# Altium schematic sheet [14] PWR2.SchDoc("[14] PWR2")
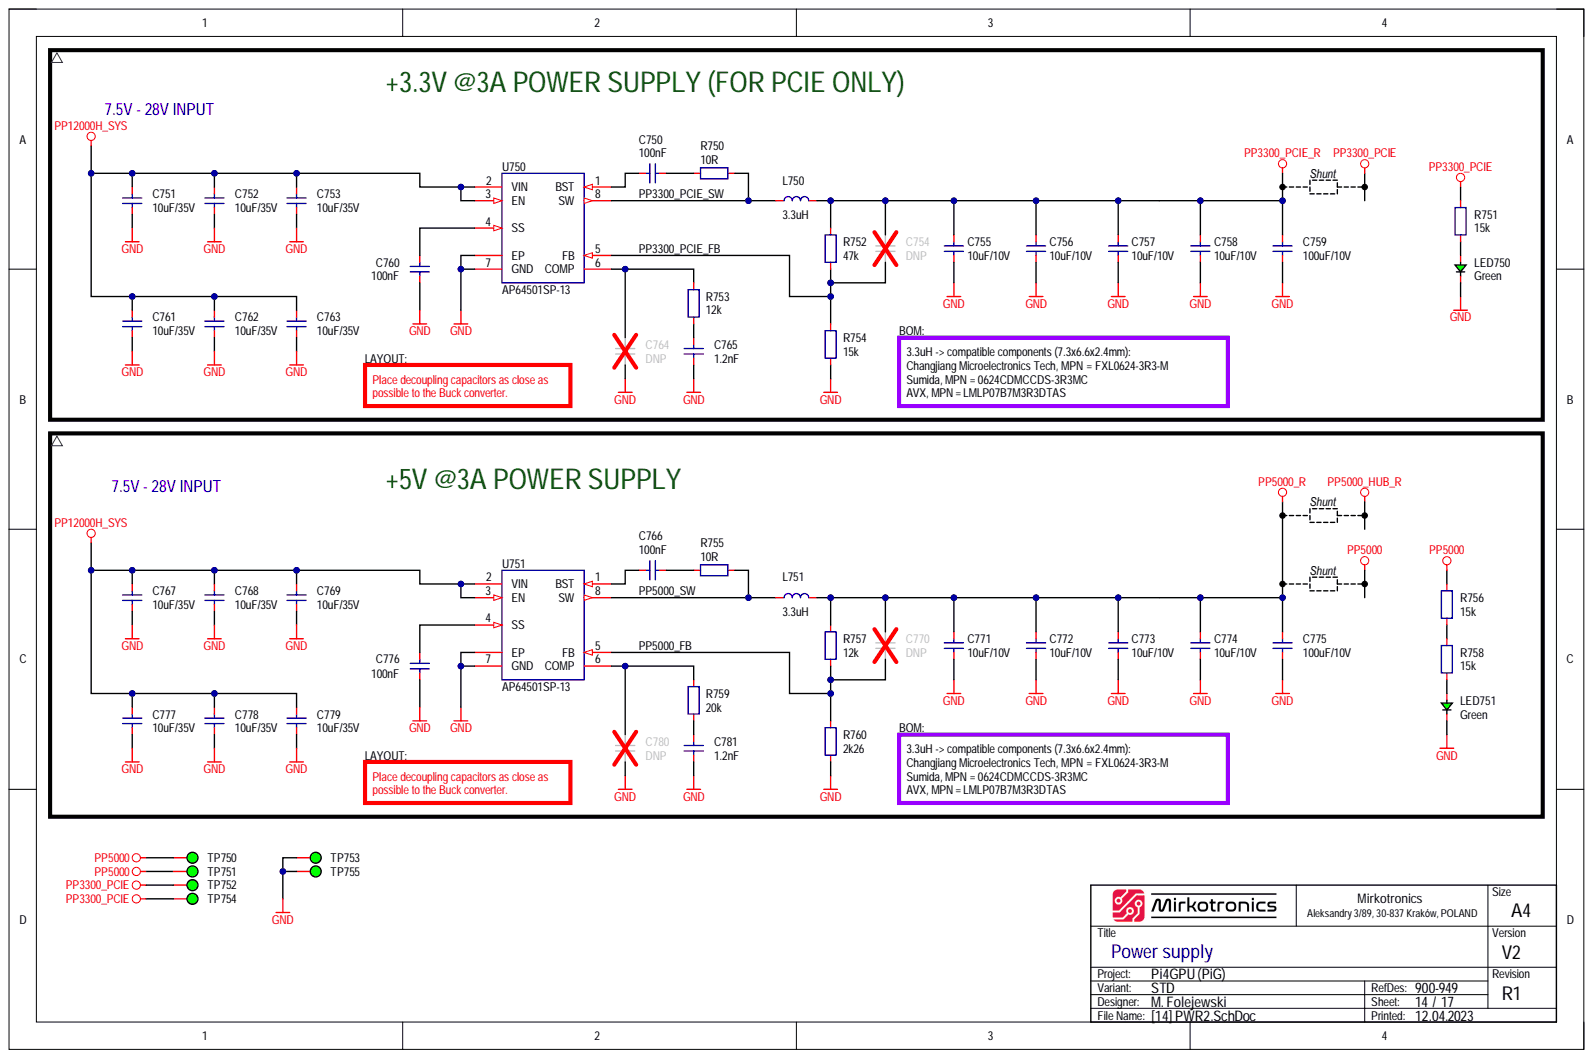
[diagram p0: [14] PWR2.SchDoc]

## Parts
C750  100nF  [CAP_0603_R]
C751  10uF/35V  [CAP_1206_R]
C752  10uF/35V  [CAP_1206_R]
C753  10uF/35V  [CAP_1206_R]
C754  DNP  [CAP_0603_R]
C755  10uF/10V  [CAP_0603_R]
C756  10uF/10V  [CAP_0603_R]
C757  10uF/10V  [CAP_0603_R]
C758  10uF/10V  [CAP_0603_R]
C759  100uF/10V  [CAP_1206_R]
C760  100nF  [CAP_0603_R]
C761  10uF/35V  [CAP_1206_R]
C762  10uF/35V  [CAP_1206_R]
C763  10uF/35V  [CAP_1206_R]
C764  DNP  [CAP_0603_R]
C765  1.2nF  [CAP_0603_R]
C766  100nF  [CAP_0603_R]
C767  10uF/35V  [CAP_1206_R]
C768  10uF/35V  [CAP_1206_R]
C769  10uF/35V  [CAP_1206_R]
C770  DNP  [CAP_0603_R]
C771  10uF/10V  [CAP_0603_R]
C772  10uF/10V  [CAP_0603_R]
C773  10uF/10V  [CAP_0603_R]
C774  10uF/10V  [CAP_0603_R]
C775  100uF/10V  [CAP_1206_R]
C776  100nF  [CAP_0603_R]
C777  10uF/35V  [CAP_1206_R]
C778  10uF/35V  [CAP_1206_R]
C779  10uF/35V  [CAP_1206_R]
C780  DNP  [CAP_0603_R]
C781  1.2nF  [CAP_0603_R]
L750  3.3uH  [IND_SUM_0624CDMCCDS_700X660X240_R]
L751  3.3uH  [IND_SUM_0624CDMCCDS_700X660X240_R]
LED750  Green  [LED_0805_GRN_R]
LED751  Green  [LED_0805_GRN_R]
R750  10R  [RES_0603_R]
R751  15k  [RES_0603_R]
R752  47k  [RES_0603_R]
R753  12k  [RES_0603_R]
R754  15k  [RES_0603_R]
R755  10R  [RES_0603_R]
R756  15k  [RES_0603_R]
R757  12k  [RES_0603_R]
R758  15k  [RES_0603_R]
R759  20k  [RES_0603_R]
R760  2k26  [RES_0603_R]
TP750  ICT  [PCB_TP_ICT_100]  (value not drawn)  (no BOM)
TP751  ICT  [PCB_TP_ICT_100]  (value not drawn)  (no BOM)
TP752  ICT  [PCB_TP_ICT_100]  (value not drawn)  (no BOM)
TP753  ICT  [PCB_TP_ICT_100]  (value not drawn)  (no BOM)
TP754  ICT  [PCB_TP_ICT_100]  (value not drawn)  (no BOM)
TP755  ICT  [PCB_TP_ICT_100]  (value not drawn)  (no BOM)
U750  AP64501SP-13  [ICC_SOIC8N_TP_R]
U751  AP64501SP-13  [ICC_SOIC8N_TP_R]

## Nets
GND: C751.1 C752.1 C753.1 C755.1 C756.1 C757.1 C758.1 C759.1 C760.1 C761.1 C762.1 C763.1 C764.1 C765.1 C767.1 C768.1 C769.1 C771.1 C772.1 C773.1 C774.1 C775.1 C776.1 C777.1 C778.1 C779.1 C780.1 C781.1 LED750.1 LED751.1 R754.1 R760.1 TP753.1 TP755.1 U750.7(GND) U750.EP U751.7(GND) U751.EP
PP3300_PCIE: R751.2 TP752.1 TP754.1
PP3300_PCIE_R: C754.2 C755.2 C756.2 C757.2 C758.2 C759.2 L750.2 R752.2
PP5000: R756.2 TP750.1 TP751.1
PP5000_R: C770.2 C771.2 C772.2 C773.2 C774.2 C775.2 L751.2 R757.2
PP12000H_SYS: C751.2 C752.2 C753.2 C761.2 C762.2 C763.2 C767.2 C768.2 C769.2 C777.2 C778.2 C779.2 U750.2(VIN) U750.3(EN) U751.2(VIN) U751.3(EN)
PP3300_PCIE_FB: C754.1 R752.1 R754.2 U750.5(FB)
PP3300_PCIE_SW: L750.1 R750.2 U750.8(SW)
PP5000_FB: C770.1 R757.1 R760.2 U751.5(FB)
PP5000_SW: L751.1 R755.2 U751.8(SW)
NetC750_1: C750.1 U750.1(BST)
NetC750_2: C750.2 R750.1
NetC760_2: C760.2 U750.4(SS)
NetC764_2: C764.2 R753.2 U750.6(COMP)
NetC765_2: C765.2 R753.1
NetC766_1: C766.1 U751.1(BST)
NetC766_2: C766.2 R755.1
NetC776_2: C776.2 U751.4(SS)
NetC780_2: C780.2 R759.2 U751.6(COMP)
NetC781_2: C781.2 R759.1
NetLED750_2: LED750.2 R751.1
NetLED751_2: LED751.2 R758.1
NetR756_1: R756.1 R758.2
PP5000_HUB_R:

## Library data of the parts
C750 C760 C766 C776 = CAP-0008: Capacitor; MLCC; 100nF; TBD; TBD; TBD; TBD; SMD; 0603 (1608);; Database Table Name: CAPACITOR$; Component Kind: Standard; Component Subtype: MLCC; Component Type: Capacitor; Manufacturer: TBD; Mounting Type: SMD; MPN: TBD; Package (Case): 0603 (1608); Supplier: ?; Supplier 1: LCSC; Supplier Part Number 1: C14663; Value: 100nF; Library Name: MirFol_db_local.DbLib
C751-C753 C759 C761-C763 C767-C769 C775 C777-C779 = CAP-0025: Capacitor; MLCC; GENERIC; TBD; TBD; TBD; TBD; SMD; 1206 (3216);; Database Table Name: CAPACITOR$; Component Kind: Standard; Component Subtype: MLCC; Component Type: Capacitor; Manufacturer: GENERIC; Mounting Type: SMD; MPN: GENERIC; Package (Case): 1206 (3216); Supplier: ?; Supplier 1: LCSC; Library Name: MirFol_db_local.DbLib
  C751-C753 C761-C763 C767-C769 C777-C779: Supplier Part Number 1: C92797; Value: 10uF/35V
  C759 C775: Supplier Part Number 1: C15008; Value: 100uF/10V
C754-C758 C764 C765 C770-C774 C780 C781 = CAP-0023: Capacitor; MLCC; GENERIC; TBD; TBD; TBD; TBD; SMD; 0603 (1608);; Database Table Name: CAPACITOR$; Component Kind: Standard; Component Subtype: MLCC; Component Type: Capacitor; Manufacturer: GENERIC; Mounting Type: SMD; MPN: GENERIC; Package (Case): 0603 (1608); Supplier 1: LCSC; Library Name: MirFol_db_local.DbLib
  C754 C764 C770 C780: Capacitance: DNP; Component Body: TBD; Component Height: TBD; Dielectric: TBD; Features: TBD; ID: CAP-0023; Operating Temperature: TBD; Packaging Type: TBD; ROHS Status: TBD; Tolerance: TBD; Value: DNP; Voltage Rating: TBD
  C755-C758 C771-C774: Supplier: ?; Supplier Part Number 1: C19702; Value: 10uF/10V
  C765 C781: Capacitance: 1.2nF; Component Body: TBD; Component Height: TBD; Dielectric: TBD; Features: TBD; ID: CAP-0023; Operating Temperature: TBD; Packaging Type: TBD; ROHS Status: TBD; Supplier Part Number 1: C1853470; Tolerance: TBD; Value: 1.2nF; Voltage Rating: TBD
L750 L751 = IND: Inductor;; Manufacturer: Changjiang Microelectronics Tech; MPN: FXL0624-3R3-M; Component Subtype: Power Inductor; Package (Case): SMD; Component Kind: Standard; Component Type: Inductor; Mounting Type: SMD; Supplier: ?; Supplier 1: LCSC; Supplier Part Number 1: C524605; Value: 3.3uH; Library Name: MirFol_lib.SchLib
LED750 LED751 = DIO_LED_GRN: Diode; LED; Green;; Manufacturer: Foshan NationStar Optoelectronics; MPN: NCD0805G1; Component Subtype: LED Diode; Package (Case): 0805 (2012); Component Kind: Standard; Component Type: LED; Mounting Type: SMD; Supplier: LCSC; Supplier 1: LCSC; Supplier Part Number 1: C84260; Value: Green; Library Name: MirFol_lib.SchLib
R750 R755 = RES-0025: Resistor; Thick Film; 10R; 1%; 100mW; 100PPM; 75V; Standard; SMD; 0603 (1608);; Manufacturer: Yageo Corporation; MPN: TBD; Component Body: 1.60 x 0.80mm; Database Table Name: RESISTOR$; Packaging Type: Tape & Reel; Component Height: 0.45mm; Component Kind: Standard; Component Subtype: Thick Film; Component Type: Resistor; Features: Standard; ID: RES-0025; Mounting Type: SMD; Operating Temperature: -55°C to +155°C; Package (Case): 0603 (1608); Power Rating: 100mW; Resistance: 10R; ROHS Status: RoHS Compliant; Supplier 1: LCSC; Supplier Part Number 1: C22859; TCR: 100PPM; Tolerance: 1%; Value: 10R; Voltage Rating: 75V; Library Name: MirFol_db_local.DbLib
R751 R756 R758 = RES-0043: Resistor; Thick Film; 15k; 1%; 100mW; 100PPM; 75V; Standard; SMD; 0603 (1608);; Manufacturer: Yageo Corporation; MPN: TBD; Database Table Name: RESISTOR$; Component Kind: Standard; Component Subtype: Thick Film; Component Type: Resistor; Mounting Type: SMD; Package (Case): 0603 (1608); Supplier: ?; Supplier 1: LCSC; Supplier Part Number 1: C22809; Value: 15k; Library Name: MirFol_db_local.DbLib
R752 = RES-0044: Resistor; Thick Film; 47k; 1%; 100mW; 100PPM; 75V; Standard; SMD; 0603 (1608);; Manufacturer: Yageo Corporation; MPN: RC0603FR-0747KL; Component Body: 1.60 x 0.80mm; Database Table Name: RESISTOR$; Packaging Type: Tape & Reel; Component Height: 0.45mm; Component Kind: Standard; Component Subtype: Thick Film; Component Type: Resistor; Features: Standard; ID: RES-0044; Mounting Type: SMD; Operating Temperature: -55°C to +155°C; Package (Case): 0603 (1608); Power Rating: 100mW; Resistance: 47k; ROHS Status: RoHS Compliant; Supplier 1: LCSC; Supplier Part Number 1: C25819; TCR: 100PPM; Tolerance: 1%; Value: 47k; Voltage Rating: 75V; Library Name: MirFol_db_local.DbLib
R753 R757 = RES-0053: Resistor; Thick Film; 12k; 1%; 100mW; 100PPM; 75V; Standard; SMD; 0603 (1608);; Manufacturer: Yageo Corporation; MPN: TBD; Component Body: 1.60 x 0.80mm; Database Table Name: RESISTOR$; Packaging Type: Tape & Reel; Component Height: 0.45mm; Component Kind: Standard; Component Subtype: Thick Film; Component Type: Resistor; Features: Standard; ID: RES-0053; Mounting Type: SMD; Operating Temperature: -55°C to +155°C; Package (Case): 0603 (1608); Power Rating: 100mW; Resistance: 12k; ROHS Status: RoHS Compliant; Supplier 1: LCSC; Supplier Part Number 1: C22790; TCR: 100PPM; Tolerance: 1%; Value: 12k; Voltage Rating: 75V; Library Name: MirFol_db_local.DbLib
R754 R759 = RES-0054: Resistor; Thick Film; GENERIC; TBD; TBD; TBD; TBD; TBD; SMD; 0603 (1608);; Component Body: 1.60 x 0.80mm; Database Table Name: RESISTOR$; Component Height: TBD; Component Kind: Standard; Component Subtype: Thick Film; Component Type: Resistor; Features: TBD; ID: RES-0054; Manufacturer: GENERIC; Mounting Type: SMD; MPN: GENERIC; Operating Temperature: TBD; Package (Case): 0603 (1608); Packaging Type: TBD; Power Rating: TBD; ROHS Status: TBD; Supplier 1: LCSC; TCR: TBD; Tolerance: TBD; Voltage Rating: TBD; Library Name: MirFol_db_local.DbLib
  R754: Resistance: 15k; Supplier Part Number 1: C22809; Value: 15k
  R759: Resistance: 20k; Supplier Part Number 1: C4184; Value: 20k
R760 = RES-0033: Resistor; Thick Film; 2k26; 1%; 100mW; 100PPM; 75V; Standard; SMD; 0603 (1608);; Manufacturer: Yageo Corporation; MPN: TBD; Component Body: 1.60 x 0.80mm; Database Table Name: RESISTOR$; Packaging Type: Tape & Reel; Component Height: 0.45mm; Component Kind: Standard; Component Subtype: Thick Film; Component Type: Resistor; Features: Standard; ID: RES-0033; Mounting Type: SMD; Operating Temperature: -55°C to +155°C; Package (Case): 0603 (1608); Power Rating: 100mW; Resistance: 2k26; ROHS Status: RoHS Compliant; Supplier 1: LCSC; Supplier Part Number 1: C22904; TCR: 100PPM; Tolerance: 1%; Value: 2k26; Voltage Rating: 75V; Library Name: MirFol_db_local.DbLib
TP750-TP755 = PCB_TP_ICT: Test Point (ICT); Specification: Testpoint (for ICT), SMD pad; Component Type: Testpoint (for ICT); Manufacturer: -; Mounting Type: Non component; MPN: -; Value: ICT; Library Name: MirFol_lib.SchLib
U750 U751 = ICC_DDI_AP64501_SO8_EP: IC; Buck regulator;; Manufacturer: Diodes Incorporated; Specification: Synchronous step-down DC-DC converter, 3.8V to 40V input, 5A, 570kHz, SOIC8 package; Component Kind: Standard; Component Type: IC; Mounting Type: SMD; MPN: AP64501SP-13; Operating Temperature: -40°C to +85°C; Package: SOIC8; Supplier 1: LCSC; Supplier Part Number 1: C2071517; Value: AP64501SP-13; Library Name: Pineberry_Lib.SchLib
